# Altium schematic sheet Sheet3.SchDoc(Sheet3)
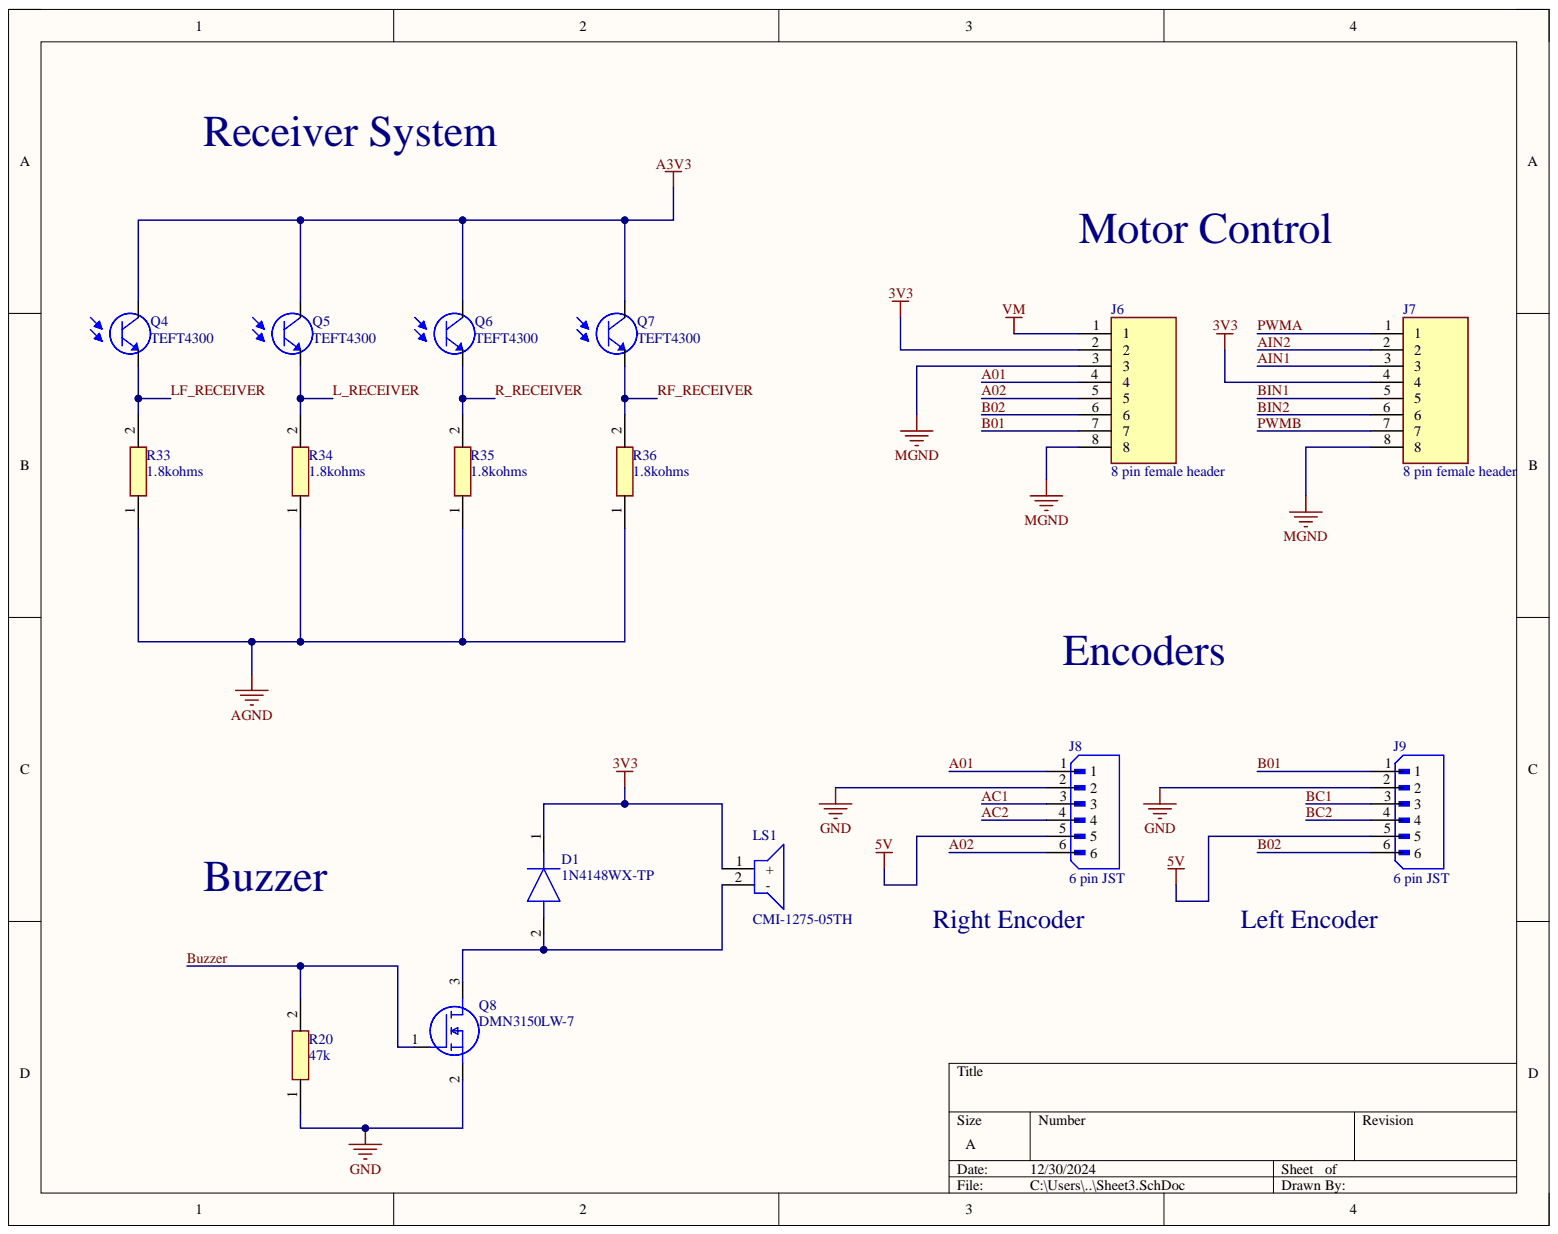
[diagram p0: Sheet3.SchDoc]

## Parts
D1  1N4148WX-TP  [SOD2512X130N]
J6  8 pin female header  [RHDR8W76P0X254_1X8_2032X356X864P]
J7  8 pin female header  [RHDR8W76P0X254_1X8_2032X356X864P]
J8  6 pin JST  [JST_B6B-XH-A(LF)(SN)]
J9  6 pin JST  [JST_B6B-XH-A(LF)(SN)]
LS1  CMI-1275-05TH  [PB1220P05Q]
Q4  TEFT4300  [TEFT4300]
Q5  TEFT4300  [TEFT4300]
Q6  TEFT4300  [TEFT4300]
Q7  TEFT4300  [TEFT4300]
Q8  DMN3150LW-7  [SOT65P210X110-3N]
R20  47k  [RESC1005X40N]
R33  1.8kohms  [RESC1608X55N]
R34  1.8kohms  [RESC1608X55N]
R35  1.8kohms  [RESC1608X55N]
R36  1.8kohms  [RESC1608X55N]

## Nets
3V3: D1.1 J6.2 J7.4 LS1.1
5V: J8.5 J9.5
A3V3: Q4.C Q5.C Q6.C Q7.C
AGND: R33.1 R34.1 R35.1 R36.1
GND: J8.2 J9.2 Q8.2 R20.1
MGND: J6.3 J6.8 J7.8
VM: J6.1
A01: J6.4 J8.1
A02: J6.5 J8.6
AC1: J8.3
AC2: J8.4
AIN1: J7.3
AIN2: J7.2
B01: J6.7 J9.1
B02: J6.6 J9.6
BC1: J9.3
BC2: J9.4
BIN1: J7.5
BIN2: J7.6
Buzzer: Q8.1 R20.2
L_RECEIVER: Q5.E R34.2
LF_RECEIVER: Q4.E R33.2
PWMA: J7.1
PWMB: J7.7
R_RECEIVER: Q6.E R35.2
RF_RECEIVER: Q7.E R36.2
NetD1_2: D1.2 LS1.2 Q8.3

## Library data of the parts
D1 = 1N4148WX-TP: Diode; Manufacturer_Name: Vishay; Component Kind: Standard; Datasheet Link: https://www.mouser.co.uk/datasheet/2/258/1N4148WX_SOD_323_-3326905.pdf; Height: 1.3mm; Library Name: Schlib1.SchLib; Manufacturer_Part_Number: 1N4148WX-TP
J6 J7 = 8 pin female header: Connector; Manufacturer_Name: TE Connectivity; Manufacturer_Part_Number: 5-534237-6; Arrow Part Number: 5-534237-6; Arrow Price/Stock: https://www.arrow.com/en/products/5-534237-6/te-connectivity; Component Kind: Standard; Datasheet Link: https://www.te.com/commerce/DocumentDelivery/DDEController?Action=showdoc&DocId=Customer+Drawing%7F534237%7FR5%7Fpdf%7FEnglish%7FENG_CD_534237_R5.pdf%7F5-534237-6; Height: 8.64mm; Library Name: Schlib1.SchLib; Mouser Part Number: 571-5-534237-6; Mouser Price/Stock: https://www.mouser.co.uk/ProductDetail/TE-Connectivity/5-534237-6?qs=GYgf5PdsjznTVr8N%252BLqCeg%3D%3D
J8 J9 = 6 pin JST: Connector Header Through Hole 6 position 0.098 (2.50mm); MF: JST Sales; MP: B6B-XH-A(LF)(SN); Check_prices: https://www.snapeda.com/parts/B6B-XH-A(LF)(SN)/JST+Sales+America+Inc./view-part/?ref=eda; Component Kind: Standard; Library Name: Schlib1.SchLib; Package: None; SnapEDA_Link: https://www.snapeda.com/parts/B6B-XH-A(LF)(SN)/JST+Sales+America+Inc./view-part/?ref=snap
LS1 = CMI-1275-05TH: Loudspeaker or Buzzer; Manufacturer_Name: Same Sky; Component Kind: Standard; Datasheet Link: https://www.sameskydevices.com/product/resource/cmi-1275-05th.pdf; Height: 8mm; Library Name: Schlib1.SchLib; Manufacturer_Part_Number: CMI-1275-05TH; Mouser Part Number: 179-CMI-1275-05TH; Mouser Price/Stock: https://www.mouser.co.uk/ProductDetail/Same-Sky/CMI-1275-05TH?qs=olJun0bQHM8CTN7pfxW40w%3D%3D
Q4-Q7 = TEFT4300: Phototransistor 925nm Top View Radial, 3mm Dia _T-1_; MF: Vishay Semiconductor; Availability: Unavailable; Component Kind: Standard; Library Name: Schlib1.SchLib; MP: TEFT4300; Package: Radial Vishay; Price: None; SnapEDA_Link: https://www.snapeda.com/parts/TEFT4300/Vishay%20Semiconductor%20Opto%20Division/view-part/213585/?ref=snap
Q8 = DMN3150LW-7: MOSFET (N-Channel); Manufacturer_Name: Diodes Incorporated; Arrow Part Number: DMN3150LW-7; Arrow Price/Stock: https://www.arrow.com/en/products/dmn3150lw-7/diodes-incorporated; Component Kind: Standard; Datasheet Link: https://www.diodes.com/assets/Datasheets/ds31514.pdf; Height: 1.1mm; Manufacturer_Part_Number: DMN3150LW-7; Mouser Part Number: 621-DMN3150LW-7; Mouser Price/Stock: https://www.mouser.co.uk/ProductDetail/Diodes-Incorporated/DMN3150LW-7?qs=ptj1V1atRAqvGijpY%2FDU4Q%3D%3D
R20 = RN73H1ETTP4702F50: 47kohm 1% 50v SMD thin; Manufacturer_Name: KOA Speer; Component Kind: Standard; Datasheet Link: https://datasheet.datasheetarchive.com/originals/distributors/Datasheets_SAMA/d16ea0929aca8c9bbbb37f20c24edc3e.pdf; Height: 0.4mm; Library Name: Schlib1.SchLib; Manufacturer_Part_Number: RN73H1ETTP4702F50; Mouser Part Number: 660-RN73H1ETP4702F50; Mouser Price/Stock: https://www.mouser.co.uk/ProductDetail/KOA-Speer/RN73H1ETTP4702F50?qs=9tvNVxbbcEof3GV0enxvDw%3D%3D
R33-R36 = CPF0603B1K8E1: thin SMD resistor 1.55mm length 50V 0.1%; Manufacturer_Name: TE Connectivity; Component Kind: Standard; Datasheet Link: https://www.te.com/commerce/DocumentDelivery/DDEController?Action=srchrtrv&DocNm=8-1773459-4_ELEVATOR_ESCALATOR_SOLUTIONS&DocType=Data%20Sheet&DocLang=English&PartCntxt=6-1676481-0&DocFormat=pdf; Height: 0.55mm; Library Name: Schlib1.SchLib; Manufacturer_Part_Number: CPF0603B1K8E1
